# Altium schematic sheet TRIFASE.SchDoc(TRIFASE)
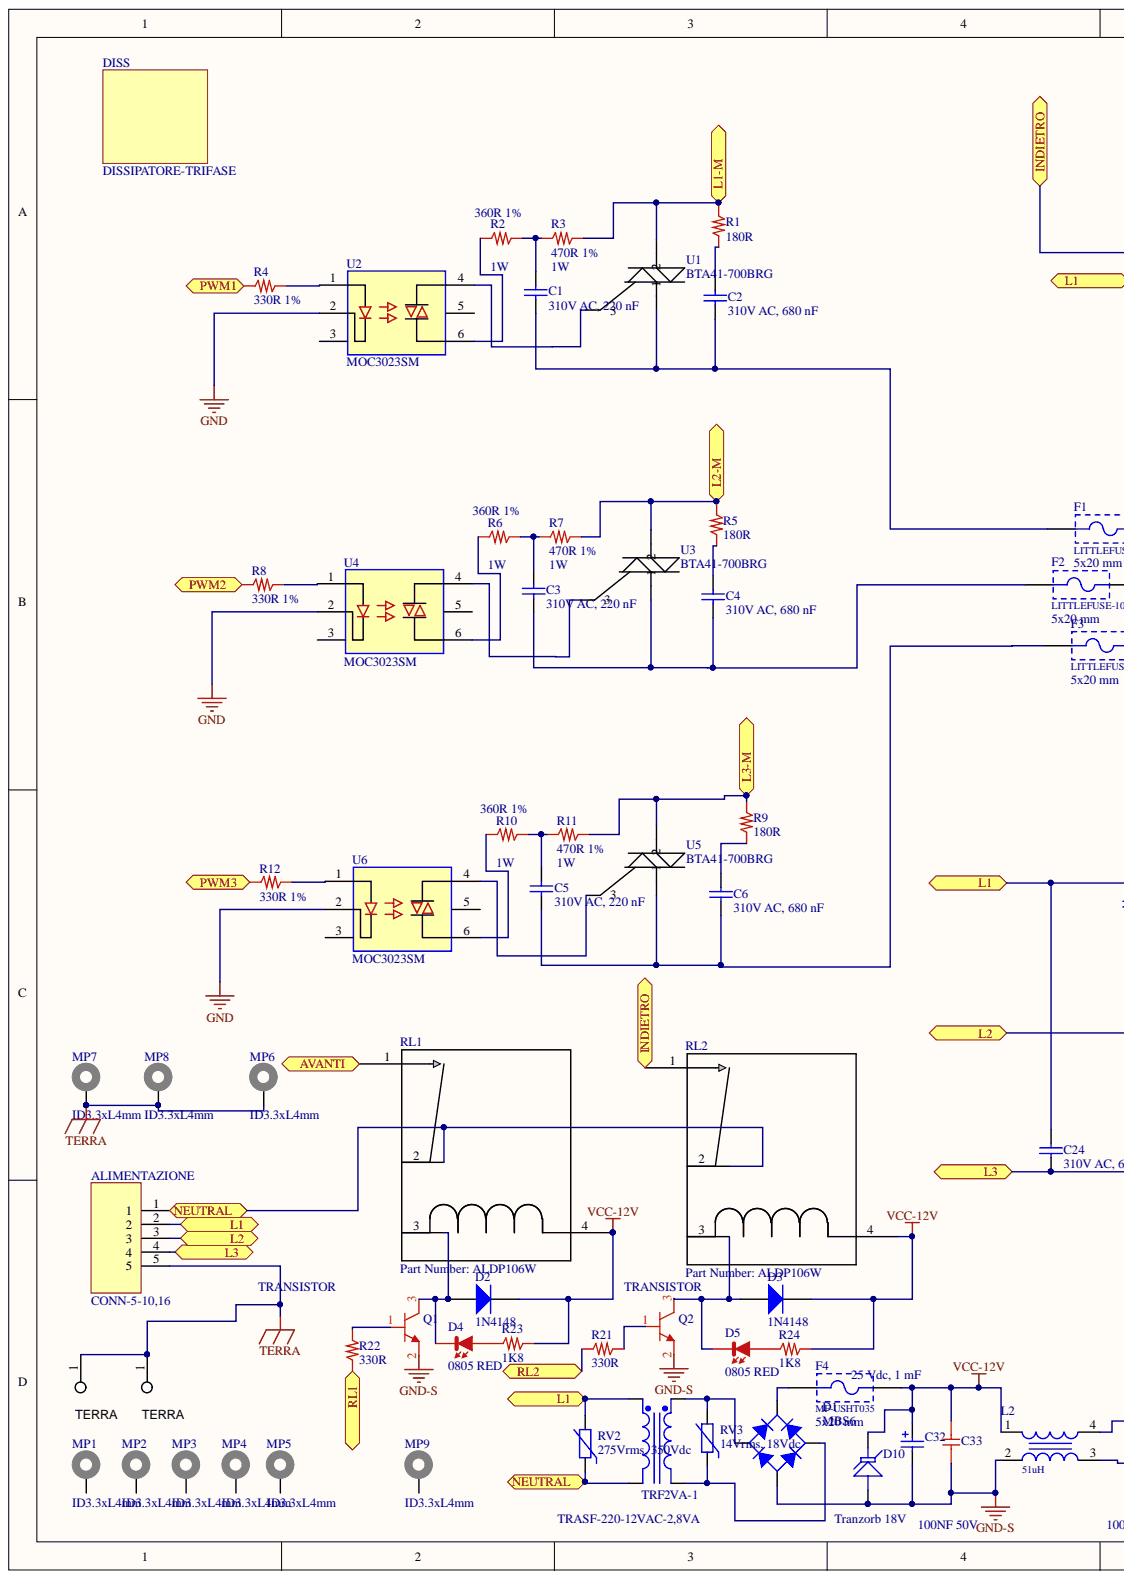
[diagram p0: TRIFASE.SchDoc, left]
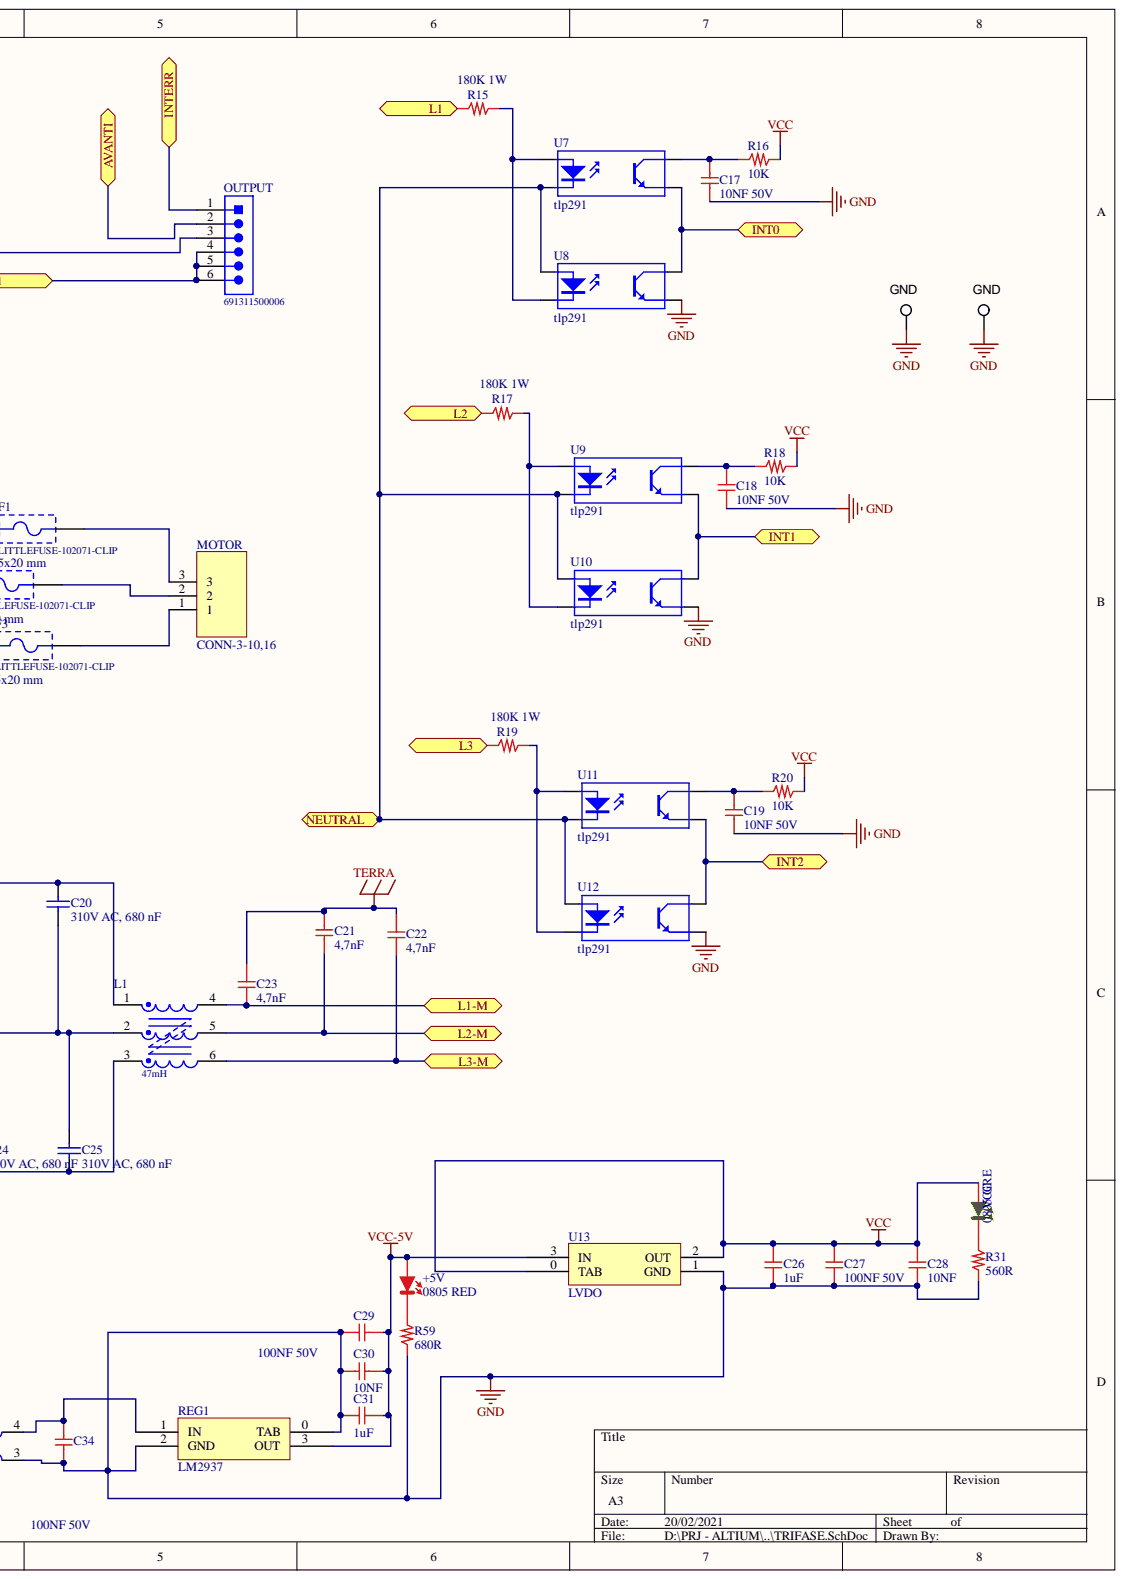
[diagram p1: TRIFASE.SchDoc, right]

## Parts
+5V  0805 RED  [0805_Red]
ALIMENTAZIONE  CONN-5-10,16  [CONN-5-10,16]
C1  310V AC, 220 nF  value 220 nF  [WCAP-FTXX_26x6x15]
C2  310V AC, 680 nF  value 680 nF  [WCAP-FTXX_31x10.5x20]
C3  310V AC, 220 nF  value 220 nF  [WCAP-FTXX_26x6x15]
C4  310V AC, 680 nF  value 680 nF  [WCAP-FTXX_31x10.5x20]
C5  310V AC, 220 nF  value 220 nF  [WCAP-FTXX_26x6x15]
C6  310V AC, 680 nF  value 680 nF  [WCAP-FTXX_31x10.5x20]
C17  10NF 50V  [0603_c]
C18  10NF 50V  [0603_c]
C19  10NF 50V  [0603_c]
C20  310V AC, 680 nF  value 680 nF  [WCAP-FTXX_31x10.5x20]
C21  4,7nF  [VAR_S10K275E2]
C22  4,7nF  [VAR_S10K275E2]
C23  4,7nF  [VAR_S10K275E2]
C24  310V AC, 680 nF  value 680 nF  [WCAP-FTXX_31x10.5x20]
C25  310V AC, 680 nF  value 680 nF  [WCAP-FTXX_31x10.5x20]
C26  1uF  [0603_c]
C27  100NF 50V  [0603_c]
C28  10NF  [0603_c]
C29  100NF 50V  [0603_c]
C30  10NF  [0603_c]
C31  1uF  [0603_c]
C32  25 Vdc, 1 mF  value 1 mF  [WCAP-ATLI_13x20x5]
C33  100NF 50V  [0603_c]
C34  100NF 50V  [0603_c]
D1  MBS6  [HD01-T SOP4]
D2  1N4148  [1N4148]
D3  1N4148  [1N4148]
D4  0805 RED  [0805_Red]
D5  0805 RED  [0805_Red]
D10  Tranzorb 18V  [DO-214AA]
DISS
F1  LITTLEFUSE-102071-CLIP  [LITTLEFUSE-102071-CLIP]
F2  LITTLEFUSE-102071-CLIP  Fuse Size: 5x20 mm  [LITTLEFUSE-102071-CLIP]
F3  LITTLEFUSE-102071-CLIP  Fuse Size: 5x20 mm  [LITTLEFUSE-102071-CLIP]
F4  MF-USHT035  [MF-USHT035]
GND  TEST POINT  [Keystone5012]  (value not drawn)
GND  TEST POINT  [Keystone5012]  (value not drawn)
L1  47mH  [WE-TPB HV_Type 4XL_744839047160]
L2  51uH  [WE-SL2]
MOTOR  CONN-3-10,16  [CONN-3-10,16]
MP1  ID3.3xL4mm  [9774040960]
MP2  ID3.3xL4mm  [9774040960]
MP3  ID3.3xL4mm  [9774040960]
MP4  ID3.3xL4mm  [9774040960]
MP5  ID3.3xL4mm  [9774040960]
MP6  ID3.3xL4mm  [9774040960]
MP7  ID3.3xL4mm  [9774040960]
MP8  ID3.3xL4mm  [9774040960]
MP9  ID3.3xL4mm  [9774040960]
OUTPUT  691311500006  [691311500006]
Q1  TRANSISTOR  [SOT23]
Q2  TRANSISTOR  [SOT23]
R1  180R  [ResAxsP1000]
R2  360R 1%  Potenza: 1W  [2512_R]
R3  470R 1%  [2512_R]
R4  330R 1%  [0805_R]
R5  180R  [ResAxsP1000]
R6  360R 1%  Potenza: 1W  [2512_R]
R7  470R 1%  [2512_R]
R8  330R 1%  [0805_R]
R9  180R  [ResAxsP1000]
R10  360R 1%  Potenza: 1W  [2512_R]
R11  470R 1%  [2512_R]
R12  330R 1%  [0805_R]
R15  180K 1W  [2512_R]
R16  10K  [0603_R]
R17  180K 1W  [2512_R]
R18  10K  [0603_R]
R19  180K 1W  [2512_R]
R20  10K  [0603_R]
R21  330R  [0603_R]
R22  330R  [0603_R]
R23  1K8  [0805_R]
R24  1K8  [0805_R]
R31  560R  [0603_R]
R59  680R  [0603_R]
REG1  LM2937  [SOT-223]
RL1  Part Number: ALDP106W  [PAN_ALD]
RL2  Part Number: ALDP106W  [PAN_ALD]
RV2  275Vrms, 350Vdc  [WE-VD_14mm_820442711E]
RV3  14Vrms, 18Vdc  [WE-VD_5mm_820551406]
TERRA  5012  [FASTOM-TERRA]  (value not drawn)
TERRA  5012  [FASTOM-TERRA]  (value not drawn)
TRASF-220-12VAC-2,8VA  TRF2VA-1  [2VA-1S]
U1  BTA41-700BRG  [TOP3_STM -90]
U2  MOC3023SM  [MOC3023SM]
U3  BTA41-700BRG  [TOP3_STM -90]
U4  MOC3023SM  [MOC3023SM]
U5  BTA41-700BRG  [TOP3_STM -90]
U6  MOC3023SM  [MOC3023SM]
U7  tlp291  [SO4_N]
U8  tlp291  [SO4_N]
U9  tlp291  [SO4_N]
U10  tlp291  [SO4_N]
U11  tlp291  [SO4_N]
U12  tlp291  [SO4_N]
U13  LVDO  [SOT-223]
VCC  0805 GRE  [0805_Green]

## Ports
AVANTI, INDIETRO, INT0, INT1, INT2, INTERR, L1, L1-M, L2, L2-M, L3, L3-M, NEUTRAL, PWM1, PWM2, PWM3, RL1, RL2

## Nets
GND: C17.2 C18.2 C19.2 C26.1 C27.1 C28.1 C29.1 C30.1 C31.1 C34.2 GND.1 L2.3 R31.2 R59.2 REG1.0(TAB) REG1.2(GND) U2.2 U4.2 U6.2 U8.3 U10.3 U12.3 U13.1(GND)
GND-S: C32.2 C33.2 D1.2 D10.2 L2.2 Q1.2 Q2.2
TERRA: ALIMENTAZIONE.5 C21.1 C22.1 C23.1 MP6.1 MP7.1 MP8.1 TERRA.1
VCC: C26.2 C27.2 C28.2 R16.2 R18.2 R20.2 U13.0(TAB) U13.2(OUT) VCC.A
VCC-5V: +5V.A C29.2 C30.2 C31.2 REG1.3(OUT) U13.3(IN)
VCC-12V: C32.1 C33.1 D2.2 D3.2 D10.1 F4.2 L2.1 R23.2 R24.2 RL1.4 RL2.4
AVANTI: OUTPUT.2 RL1.1 ; port AVANTI
INDIETRO: OUTPUT.3 RL2.1 ; port INDIETRO
INT0: U7.3 U8.4 ; port INT0
INT1: U9.3 U10.4 ; port INT1
INT2: U11.3 U12.4 ; port INT2
INTERR: OUTPUT.1 ; port INTERR
L1: ALIMENTAZIONE.2 C20.2 C24.2 L1.1 OUTPUT.4 OUTPUT.5 OUTPUT.6 R15.1 RV2.2 TRASF-220-12VAC-2,8VA.1 ; port L1
L1-M: C23.2 L1.4 R1.1 R3.2 U1.2 ; port L1-M
L2: ALIMENTAZIONE.3 C20.1 C25.2 L1.2 R17.1 ; port L2
L2-M: C21.2 L1.5 R5.1 R7.2 U3.2 ; port L2-M
L3: ALIMENTAZIONE.4 C24.1 C25.1 L1.3 R19.1 ; port L3
L3-M: C22.2 L1.6 R9.1 R11.2 U5.2 ; port L3-M
NEUTRAL: ALIMENTAZIONE.1 RL1.2 RL2.2 RV2.1 TRASF-220-12VAC-2,8VA.2 U7.2 U8.1 U9.2 U10.1 U11.2 U12.1 ; port NEUTRAL
PWM1: R4.1 ; port PWM1
PWM2: R8.1 ; port PWM2
PWM3: R12.1 ; port PWM3
RL1: R22.2 ; port RL1
RL2: R21.2 ; port RL2
Net+5V_K: +5V.K R59.1
NetC1_1: C1.1 C2.1 F1.1 U1.1
NetC1_2: C1.2 R2.2 R3.1
NetC2_2: C2.2 R1.2
NetC3_1: C3.1 C4.1 F2.1 U3.1
NetC3_2: C3.2 R6.2 R7.1
NetC4_2: C4.2 R5.2
NetC5_1: C5.1 C6.1 F3.1 U5.1
NetC5_2: C5.2 R10.2 R11.1
NetC6_2: C6.2 R9.2
NetC17_1: C17.1 R16.1 U7.4
NetC18_1: C18.1 R18.1 U9.4
NetC19_1: C19.1 R20.1 U11.4
NetC34_1: C34.1 L2.4 REG1.1(IN)
NetD1_1: D1.1 F4.1
NetD1_3: D1.3(18Vdc) RV3.1 TRASF-220-12VAC-2,8VA.3
NetD1_4: D1.4 RV3.2 TRASF-220-12VAC-2,8VA.4
NetD2_1: D2.1 D4.K Q1.3 RL1.3
NetD3_1: D3.1 D5.K Q2.3 RL2.3
NetD4_A: D4.A R23.1
NetD5_A: D5.A R24.1
NetF1_2: F1.2 MOTOR.3
NetF2_2: F2.2 MOTOR.2
NetF3_2: F3.2 MOTOR.1
NetQ1_1: Q1.1 R22.1
NetQ2_1: Q2.1 R21.1
NetR2_1: R2.1 U2.6
NetR4_2: R4.2 U2.1
NetR6_1: R6.1 U4.6
NetR8_2: R8.2 U4.1
NetR10_1: R10.1 U6.6
NetR12_2: R12.2 U6.1
NetR15_2: R15.2 U7.1 U8.2
NetR17_2: R17.2 U9.1 U10.2
NetR19_2: R19.2 U11.1 U12.2
NetR31_1: R31.1 VCC.K
NetU1_3: U1.3 U2.4
NetU3_3: U3.3 U4.4
NetU5_3: U5.3 U6.4

Not connected: MP1 1; MP2 1; MP3 1; MP4 1; MP5 1; MP9 1; U2 3 5; U4 3 5; U6 3 5

## Library data of the parts
+5V D4 D5 = LED_ROSSO_0805: LED Rosso 0805; V: 2.0V; Produttore: WE; Luminosità: 150mcd; Cod. Produttore: 150080RS75000; Cod. Interno: LEDS 2AC080RS7500; Component Kind: Standard; Library Name: DiodiLED.SchLib
C1 C3 C5 = 890334026003: WCAP-FTXX Film Capacitors, 26x6x15mm, 310V AC, 220 nF; Value: 220 nF; Rated Voltage: 310V AC; Size: 26x6x15mm; Published Date: Sep. 2017; Part Number: 890334026003; Operating Temperature: -40 °C to +105 °C; Library Name: WCAP-FTXX.SchLib; ComponentLink2URL: http://katalog.we-online.de/pbs/datasheet/890334026003.pdf; ComponentLink2Description: Datasheet; ComponentLink1URL: http://www.we-online.de; ComponentLink1Description: Manufacturer; Component Kind: Standard; Publisher: Wurth Elektronik
C2 C4 C6 C20 C25 = 890334027006: WCAP-FTXX Film Capacitors, 31x10.5x20mm, 310V AC, 680 nF; Rated Voltage: 310V AC; Size: 31x10.5x20mm; Published Date: Sep. 2017; Part Number: 890334027006; Operating Temperature: -40 °C to +105 °C; Library Name: WCAP-FTXX.SchLib; ComponentLink2URL: http://katalog.we-online.de/pbs/datasheet/890334027006.pdf; ComponentLink2Description: Datasheet; ComponentLink1URL: http://www.we-online.de; ComponentLink1Description: Manufacturer; Component Kind: Standard; Publisher: Wurth Elektronik
C17-C19 C27 C29 C33 C34 = 100NF 50V: Cap X7R 100nF 50V Flex Terminal; Produttore: Samsung; Cod. Produttore: CL10B104KB8SFNC; Cod. Interno: COCS50665050104; Library Name: CapSMD0603.SchLib; Component Kind: Standard; Cod. DigiKey: 1276-1936-2-ND
C21-C23 = CAP-Y-4,7: CAP CLASSEY 275V 4,7nF; Produttore: WALSIN; Costo 1000: 0,0183; Costo 100: 0,0436; Cod. Produttore: 0603X225K100CT; Cod. Interno: COCS??; ComponentLink1URL: http://it.farnell.com/walsin/0603x225k100ct/cond-mlcc-x5r-2-2uf-10v-0603-bob/dp/2495422?st=2.2uf; ComponentLink1Description: FARNELL; Component Kind: Standard; Library Name: HSW.SchLib
C24 = 890334027006: WCAP-FTXX Film Capacitors, 31x10.5x20mm, 310V AC, 680 nF; Value: 680 nF; Rated Voltage: 310V AC; Size: 31x10.5x20mm; Published Date: Sep. 2017; Part Number: 890334027006; Operating Temperature: -40 °C to +105 °C; Library Name: WCAP-FTXX.SchLib; ComponentLink2URL: http://katalog.we-online.de/pbs/datasheet/890334027006.pdf; ComponentLink2Description: Datasheet; ComponentLink1URL: http://www.we-online.de; ComponentLink1Description: Manufacturer; Component Kind: Standard; Publisher: Wurth Elektronik
C26 C31 = 1uF 10V: 2.2 µF, 10 V, ± 10%, X5R 0603; Produttore: WALSIN; Costo 1000: 0,0183; Costo 100: 0,0436; Cod. Produttore: 0603X225K100CT; Cod. Interno: COCS??; Library Name: CapSMD0603.SchLib; ComponentLink1URL: http://it.farnell.com/walsin/0603x225k100ct/cond-mlcc-x5r-2-2uf-10v-0603-bob/dp/2495422?st=2.2uf; ComponentLink1Description: FARNELL; Component Kind: Standard
C28 C30 = 10NF 50V: Cap X7R 10nF 50V; Produttore: Samsung; Cod. Produttore: CL05B103KB5NNNC; Cod. Interno: COCS 556655000103; Library Name: CapSMD0603.SchLib; Component Kind: Standard; Cod. DigiKey: 1276-1028-2-ND
C32 = 860080478020: WCAP-ATLI Aluminum Electrolytic Capacitors, 13x20mm, 25 Vdc, 1 mF; Value: 1 mF; Rated Voltage: 25 Vdc; Size: 13x20mm; Published Date: Sep. 2017; Part Number: 860080478020; Operating Temperature: -55 °C up to +105 °C; Life Cycle: 5000h; Library Name: WCAP-ATLI.SchLib; ComponentLink2URL: http://katalog.we-online.com/pbs/datasheet/860080478020.pdf; ComponentLink2Description: Datasheet; ComponentLink1URL: http://www.we-online.de; ComponentLink1Description: Manufacturer; Component Kind: Standard; Publisher: Wurth Elektronik
D1 = HD01-T: Full Wave Diode Bridge; Component Kind: Standard; Publisher: Altium Limited; Library Name: HSW.SchLib
D2 D3 = 1N4148: High Conductance Fast Diode; Published: 8-Jun-2000; PackageReference: DO-35; PackageDescription: Diode, Axial; Body 3.8 x 1.9 mm (LxDia. max), Lead Dia. 0.53 mm (max); LatestRevisionNote: Re-released for DXP Platform.; LatestRevisionDate: 17-Jul-2002; DatasheetVersion: 1997; ComponentLink2URL: http://www.fairchildsemi.com/ds/1N%2F1N4148.pdf; ComponentLink2Description: Datasheet; ComponentLink1URL: http://www.fairchildsemi.com/; ComponentLink1Description: Manufacturer Link; Component Kind: Standard; Code_JEDEC: DO-204-AH; Publisher: Altium Limited; Library Name: HSW.SchLib
D10 = Tranzorb: Transient Voltage Suppression (TVS) Diode; Published: 24-Mar-1999; PackageReference: DO-7; PackageDescription: Axial Lead Diode; LatestRevisionNote: Re-released for DXP Platform.; LatestRevisionDate: 17-Jul-2002; Component Kind: Standard; Publisher: Altium Limited; Library Name: HSW.SchLib
F1 = LITTLEFUSE-102071-CLIP: CLIP PORTAFUSIBILE -6,3X32; Part Number: 696108003002; Fuse Size: 5x20 mm; Published Date: August 2018; Packaging: Box; Operating Temperature: -30 to 120 degC; Mounting Technology: THT; ComponentLink2URL: https://katalog.we-online.de/em/datasheet/696108003002.pdf; ComponentLink2Description: Datasheet; ComponentLink1URL: http://www.we-online.de; ComponentLink1Description: Manufacturer; Component Kind: Standard; Publisher: Wurth Elektronik; Library Name: HSW.SchLib
F2 F3 = LITTLEFUSE-102071-CLIP: CLIP PORTAFUSIBILE -6,3X32; Part Number: 696108003002; Published Date: August 2018; Packaging: Box; Operating Temperature: -30 to 120 degC; Mounting Technology: THT; ComponentLink2URL: https://katalog.we-online.de/em/datasheet/696108003002.pdf; ComponentLink2Description: Datasheet; ComponentLink1URL: http://www.we-online.de; ComponentLink1Description: Manufacturer; Component Kind: Standard; Publisher: Wurth Elektronik; Library Name: HSW.SchLib
F4 = MF-USHT035: MF-USHT035 POLIFUSE; Part Number: 696108003002; Fuse Size: 5x20 mm; Published Date: August 2018; Packaging: Box; Operating Temperature: -30 to 120 degC; Mounting Technology: THT; ComponentLink2URL: https://katalog.we-online.de/em/datasheet/696108003002.pdf; ComponentLink2Description: Datasheet; ComponentLink1URL: http://www.we-online.de; ComponentLink1Description: Manufacturer; Component Kind: Standard; Publisher: Wurth Elektronik; Library Name: HSW.SchLib
GND GND = TP-HSW: Test Point, Multipurpose, White, TH; PartNumber: 5012; Manufacturer: Keystone; ContactFinish: Silver; CompType: Test Point Loop; SymbolVersion: 2008-04-04; Supplier Part Number 2: 534-5012; Supplier Part Number 1: 36-5012-ND; Supplier 2: Mouser; Supplier 1: Digi-Key; RoHS: Y; PackageReference: White Multipurpose Testpoint; MountType: TH; Library Name: HSW.SchLib; LatestRevisionDate: 2017-01-19; ComponentLink1URL: http://www.keyelco.com/userAssets/file/M60-2p60.pdf; ComponentLink1Description: Datasheet URL; Component Kind: Standard; Color: White; Publisher: Texas Instruments
L1 = 744839047160: WE-TPB HV Three-Phase Common Mode Power Line Choke, Type 4XL, 47mH, 16A, 760V; Manufacturer: Wurth Elektronik; Rated Voltage: 760VAC; Material: Nanocrystalline; Insulation Test Voltage: 3000VAC; DC Resistance max.: 14mOhm; Size: Type 4XL; Rated Current: 16A; Published Date: April 2020; Packaging: Tray and Carton; Operating Temperature: -40 to +125degC; Mounting Technology: THT; Manufacturer Part Number: 744839047160; Library Name: WE-TPB HV_Type 4XL.SchLib; Inductance: 47mH; ComponentLink2URL: https://www.we-online.com/catalog/datasheet/744839047160.pdf; ComponentLink2Description: Datasheet; ComponentLink1URL: http://www.we-online.de; ComponentLink1Description: Manufacturer; Component Kind: Standard
L2 = 744227: WE-SL2 SMT Common Mode Line Filter, 51uH, 1000mA, 80V; Winding Style: bifilar; Leakage Inductance: 85nH; Insulation Test Voltage: 500V (AC); Impedance max.: 5500Ohm; DC Resistance max.: 0.16Ohm; Rated Voltage: 80V; Rated Current: 1000mA; Published Date: March 2019; Part Number: 744227; Packaging: Tape and Reel; Operating Temperature: -40 to +125degC; Mounting Technology: SMT; Library Name: WE-SL2.SchLib; Inductance: 51uH; ComponentLink2URL: https://katalog.we-online.com/pbs/datasheet/744227.pdf; ComponentLink2Description: Datasheet; ComponentLink1URL: http://www.we-online.de; ComponentLink1Description: Manufacturer; Component Kind: Standard; Publisher: Wurth Elektronik
MP1-MP9 = 9774040960R: WA-SMST SMT Steel Spacer with through hole, OD6mm, ID3.3xL4mm; Manufacturer: Wurth Elektronik; Surface: Tin; Outer Diameter: 6mm; Inner Diameter: 3.3mm; Published Date: May 2020; Packaging: Tape and Reel; Operating Temperature: -55 to +150degC; Mounting Technology: SMT; Material: Steel; Manufacturer Part Number: 9774040960R; Library Name: WA-SMST_ID3.3mm.SchLib; Length: 4mm; ComponentLink2URL: https://www.we-online.com/catalog/datasheet/9774040960R.pdf; ComponentLink2Description: Datasheet; ComponentLink1URL: http://www.we-online.de; ComponentLink1Description: Manufacturer; Component Kind: Standard
OUTPUT = 691311500006: Serie 311 - 5.08mm Open Vertical PCB Header WR-TBL, 6 pin; Pin Number: 6; Gender: Male; Published Date: July 2018; Pitch: 5.08 mm; Part Number: 691311500006; Packaging: Box; Operating Temperature: -40 to 105 degC; Mounting Technology: THT; Library Name: Pluggable PCB System WR-TBL_5.08 mm.SchLib; ComponentLink2URL: http://katalog.we-online.de/em/datasheet/6913115000xx.pdf; ComponentLink2Description: Datasheet; ComponentLink1URL: http://www.we-online.de; ComponentLink1Description: Manufacturer; Component Kind: Standard; Publisher: Wurth Elektronik
Q1 Q2 = BC847B: NPN Silicon Darlington Transistors; Vce: 30V; Vcb: 40V; Produttore: INFINEON; Ic: 500mA; Cod. Produttore: BCV27; Cod. Interno: TRXS ??; Component Kind: Standard; Library Name: HSW.SchLib
R1 R5 R9 = 180R: Ser PTH 180R 5W MetalOx 5%; Produttore: ??; Cod. Interno: RES 903800180; Value: 180R; Library Name: ResPTH5W.SchLib; Component Kind: Standard; Cod. Produttore: ??
R2 R3 R6 R7 R10 R11 = 120R 1% 1W: Res Thick Film 120R 1W 1%; Produttore: Vishay Dale; Cod. Produttore: CRCW2512120RFKEG; Cod. Interno: RESS 4190310A1200; Library Name: ResSMD2512.SchLib; Component Kind: Standard; Cod. DigiKey: 541-120AFCT-ND
  R3 R7 R11: Potenza: 1W
R4 R8 R12 = 300R 1%: Res Film Spesso 100mW 1M 1%; V nominale: 100V; Produttore: Panasonic; Cod. Produttore: ERA6AEB301V; Cod. Interno: ??; Potenza: 100mW; Library Name: ResSMD0805.SchLib; Component Kind: Standard; Cod. Farnell: 1670221
R15 R17 R19 = 180K 5% 1W 500V_1: 180K 5% 1W 500V; Produttore: Vishay; Cod. Produttore: CRCW2512150KJNEG; Cod. Interno: RESS 4190510A0154; Library Name: ResSMD2512.SchLib; Component Kind: Standard; Cod. Farnell: 1886539
R16 R18 R20 = 10K: Res Film Spesso 1/16W 10K 5%; Produttore: ??; Cod. Interno: RESS4166556B0103??; Library Name: ResSMD0603.SchLib; Component Kind: Standard; Cod. Produttore: ??
R21 R22 = 330R: Res Film Spesso 1/16W 330R 5%; Cod. Interno: RESS4166556B0331; Library Name: ResSMD0603.SchLib; Component Kind: Standard
R23 R24 = 1K8: Res Film Spesso 1/2W 1K8 5%; Potenza: 1/2W 5%; Cod. Interno: RESS4170555B0182??; Library Name: ResSMD0805.SchLib; Component Kind: Standard
R31 = 560R: Res Film Spesso 1/16W 560R 5%; Cod. Interno: RESS 4166556B0561; Library Name: ResSMD0603.SchLib; Component Kind: Standard
R59 = 680R: Res Film Spesso 1/16W 680R 5%; Cod. Interno: RESS 4166556B0681; Library Name: ResSMD0603.SchLib; Component Kind: Standard
RL1 RL2 = ALDP106W: No Description Available; Vendor: Panasonic; Built_By: EMA_UL_Team; Value: Value; Type: DEV; RefDes: RefDes; Manufacturer_Part_Number: ALDP106W; Component Kind: Standard; Library Name: FTR-F3.SchLib; Copyright: Copyright (C) 2018 Accelerated Designs. All rights reserved
RV2 = 820442711E: WE-VD Disk Varistor, size 14mm, 275Vrms, 350Vdc; Power Dissipation: 0.6W; Energy Absorbtion: 155J; Clamping Voltage: 710V; (Reverse) Peak Pulse Current: 6000A; (Reverse) Breakdown Voltage: 430V; (Channel) Input Capacitance: 450pF; Size: 14mm; Published Date: March 2019; Part Number: 820442711E; Packaging: Tape and Reel; Operating Temperature: -40 to +85degC; Mounting Technology: THT; DC Operating Voltage: 350Vdc; ComponentLink2URL: https://katalog.we-online.com/pbs/datasheet/820442711E.pdf; ComponentLink2Description: Datasheet; ComponentLink1URL: http://www.we-online.de; ComponentLink1Description: Manufacturer; Component Kind: Standard; AC Operating Voltage: 275Vrms; Publisher: Wurth Elektronik; Library Name: MOV_14mm.SchLib
RV3 = 820551406: WE-VD Disk Varistor, size 5mm, 14Vrms, 18Vdc; Power Dissipation: 0.01W; Energy Absorbtion: 0.7J; Clamping Voltage: 48V; (Reverse) Peak Pulse Current: 100A; (Reverse) Breakdown Voltage: 22V; (Channel) Input Capacitance: 1130pF; Size: 5mm; Published Date: March 2019; Part Number: 820551406; Packaging: Tape and Reel; Operating Temperature: -40 to +85degC; Mounting Technology: THT; DC Operating Voltage: 18Vdc; ComponentLink2URL: https://katalog.we-online.com/pbs/datasheet/820551406.pdf; ComponentLink2Description: Datasheet; ComponentLink1URL: http://www.we-online.de; ComponentLink1Description: Manufacturer; Component Kind: Standard; AC Operating Voltage: 14Vrms; Publisher: Wurth Elektronik; Library Name: MOV_5mm.SchLib
TERRA TERRA = TERRA: Test Point, Multipurpose, White, TH; Manufacturer: Keystone; ContactFinish: Silver; CompType: Test Point Loop; SymbolVersion: 2008-04-04; Supplier Part Number 2: 534-5012; Supplier Part Number 1: 36-5012-ND; Supplier 2: Mouser; Supplier 1: Digi-Key; RoHS: Y; PartNumber: 5012; PackageReference: White Multipurpose Testpoint; MountType: TH; LatestRevisionDate: 2017-01-19; ComponentLink1URL: http://www.keyelco.com/userAssets/file/M60-2p60.pdf; ComponentLink1Description: Datasheet URL; Component Kind: Standard; Color: White; Publisher: Texas Instruments; Library Name: HSW.SchLib
TRASF-220-12VAC-2,8VA = TRF2VA-1: Center-Tapped Transformer (Coupled Inductor Model); Published: 8-Jun-2000; PackageReference: TRF_5; PackageDescription: Transformer; 5 Leads; LatestRevisionNote: Re-released for DXP Platform.; LatestRevisionDate: 17-Jul-2002; Component Kind: Standard; Publisher: Altium Limited; Library Name: HSW.SchLib
U1 U3 U5 = BTA41-700BRG-90: TRIAC 40A 700V; Vendor: STMicroelectronics; SourceLibrary: StMicro_2015-03-30; Built_By: EMA_UL_Team; Type: DEV; RefDes: RefDes; Manufacturer_Part_Number: BTA41-700BRG; Component Kind: Standard; Library Name: HSW.SchLib; Copyright: Copyright (C) 2018 Accelerated Designs. All rights reserved
U2 U4 U6 = MOC3023SM: Fotoaccoppiatore, Uscita Triac,  DIP6-SMD, 5.3 kV, Non Zero Crossing; Produttore: ON SEMICONDUCTOR; Costo 1000: 0.185; Costo 100: 0.274; Component Kind: Standard; Cod. Prodotto: MOC3023SM; Cod. Farnell: 2322550; Library Name: Varie.SchLib
U7-U12 = tlp291: 4-Pin Phototransistor Optocoupler; Published: 24-Mar-1999; PackageReference: DIP-4; LatestRevisionNote: Sch pins 3 & 4 Swapped.; LatestRevisionDate: 04-Mar-2005; DatasheetVersion: Nov-2003; Component Kind: Standard; Publisher: Altium Limited; Library Name: HSW.SchLib
U13 = LD1117S33TR: REGOLATORE IN; Component Kind: Standard; Library Name: HSW.SchLib
VCC = LED_Verde_0805: LED Verde 0805; V: 3.1V; Produttore: WE; Luminosità: 450mcd; Cod. Produttore: 150080GS75000; Cod. Interno: LEDS 9AC080GS7500; Component Kind: Standard; Library Name: DiodiLED.SchLib
ALIMENTAZIONE = CONN-5-10,16: Component Kind: Standard; Library Name: HSW.SchLib
MOTOR = CONN-3-10,16: Component Kind: Standard; Library Name: HSW.SchLib
REG1 = LM2937: Component Kind: Standard; Library Name: HSW.SchLib
